# Altium schematic sheet Microcontroller.SchDoc
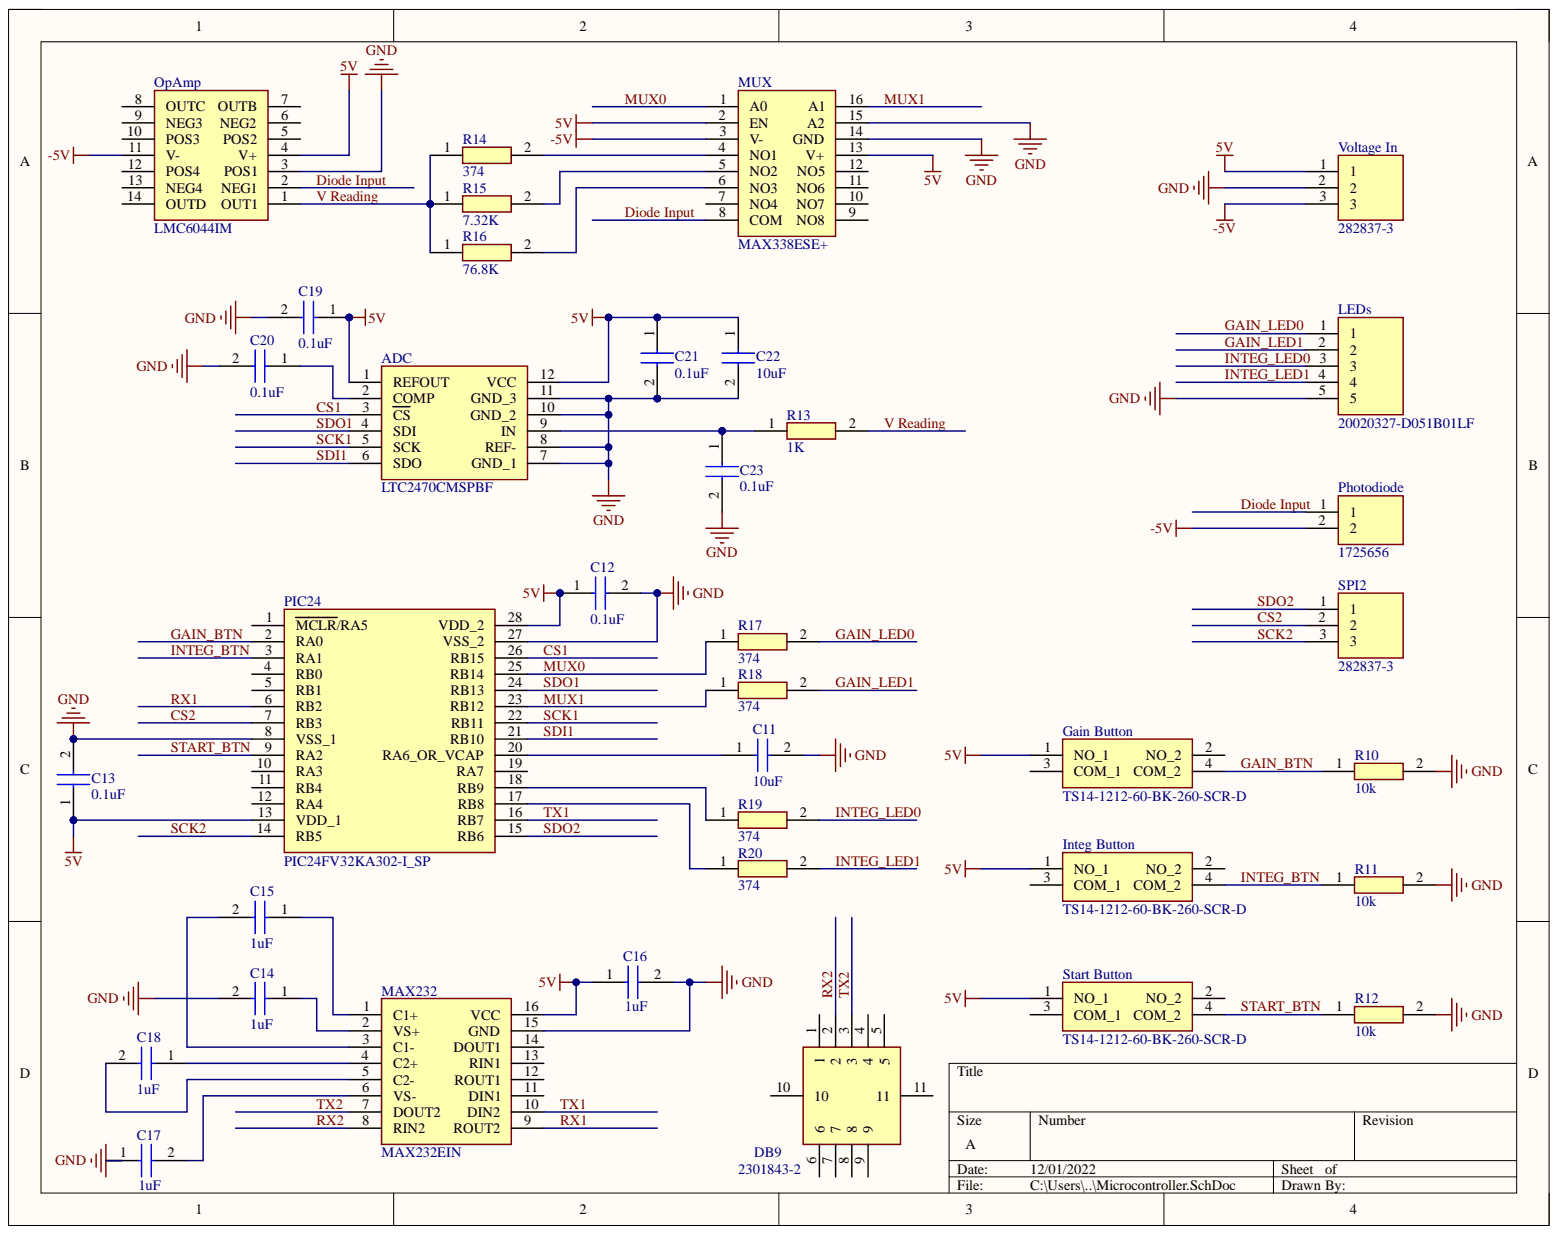
[diagram p0: Microcontroller.SchDoc]

## Parts
ADC  LTC2470CMSPBF  [SOP65P490X110-12N]
C11  10uF  [FG26]
C12  0.1uF  [RDE5C1H100J0P1H03B]
C13  0.1uF  [RDE5C1H100J0P1H03B]
C14  1uF  [K105K20X7RF5TH5]
C15  1uF  [K105K20X7RF5TH5]
C16  1uF  [K105K20X7RF5TH5]
C17  1uF  [K105K20X7RF5TH5]
C18  1uF  [K105K20X7RF5TH5]
C19  0.1uF  [RDE5C1H100J0P1H03B]
C20  0.1uF  [RDE5C1H100J0P1H03B]
C21  0.1uF  [RDE5C1H100J0P1H03B]
C22  10uF  [FG26]
C23  0.1uF  [RDE5C1H100J0P1H03B]
DB9  2301843-2  [23018432]
Gain_Button  TS14-1212-60-BK-260-SCR-D  [TS14121260BK260SCRD]
Integ_Button  TS14-1212-60-BK-260-SCR-D  [TS14121260BK260SCRD]
LEDs  20020327-D051B01LF  [20020327D051B01LF]
MAX232  MAX232EIN  [DIP794W53P254L1930H508Q16N]
MUX  MAX338ESE+  [SOIC127P600X175-16N]
OpAmp  LMC6044IM  [SOIC127P600X175-14N]
Photodiode  1725656  [1725656]
PIC24  PIC24FV32KA302-I_SP  [DIP794W56P254L3486H508Q28N]  (value not drawn)
R10  10k  [RESC3126X65N]
R11  10k  [RESC3126X65N]
R12  10k  [RESC3126X65N]
R13  1K  [RESC5025X65N]
R14  374  [RESC3126X65N]
R15  7.32K  [RESC3126X65N]
R16  76.8K  [ERJP14_(1210)]
R17  374  [RESC3126X65N]
R18  374  [RESC3126X65N]
R19  374  [RESC3126X65N]
R20  374  [RESC3126X65N]
SPI2  282837-3  [2828373]
Start_Button  TS14-1212-60-BK-260-SCR-D  [TS14121260BK260SCRD]
Voltage_In  282837-3  [2828373]

## Nets
5V: ADC.1(REFOUT) ADC.12(VCC) C12.1 C13.1 C16.1 C19.1 C21.1 C22.1 Gain_Button.1(NO_1) Integ_Button.1(NO_1) MAX232.16(VCC) MUX.2(EN) MUX.13(V+) OpAmp.4(V+) PIC24.13(VDD_1) PIC24.28(VDD_2) Start_Button.1(NO_1) Voltage_In.1
-5V: MUX.3(V-) OpAmp.11(V-) Photodiode.2 Voltage_In.3
GND: ADC.7(GND_1) ADC.8(REF-) ADC.10(GND_2) ADC.11(GND_3) C11.2 C12.2 C13.2 C14.2 C16.2 C17.1 C19.2 C20.2 C21.2 C22.2 C23.2 LEDs.5 MAX232.15(GND) MUX.14(GND) MUX.15(A2) OpAmp.3(POS1) PIC24.8(VSS_1) PIC24.27(VSS_2) R10.2 R11.2 R12.2 Voltage_In.2
CS1: ADC.3(CS) PIC24.26(RB15)
CS2: PIC24.7(RB3) SPI2.2
Diode Input: MUX.8(COM) OpAmp.2(NEG1) Photodiode.1
GAIN_BTN: Gain_Button.4(COM_2) PIC24.2(RA0) R10.1
GAIN_LED0: LEDs.1 R17.2
GAIN_LED1: LEDs.2 R18.2
INTEG_BTN: Integ_Button.4(COM_2) PIC24.3(RA1) R11.1
INTEG_LED0: LEDs.3 R19.2
INTEG_LED1: LEDs.4 R20.2
MUX0: MUX.1(A0) PIC24.25(RB14) R17.1
MUX1: MUX.16(A1) PIC24.23(RB12) R18.1
RX1: MAX232.9(ROUT2) PIC24.6(RB2)
RX2: DB9.2 MAX232.8(RIN2)
SCK1: ADC.5(SCK) PIC24.22(RB11)
SCK2: PIC24.14(RB5) SPI2.3
SDI1: ADC.6(SDO) PIC24.21(RB10)
SDO1: ADC.4(SDI) PIC24.24(RB13)
SDO2: PIC24.15(RB6) SPI2.1
START_BTN: PIC24.9(RA2) R12.1 Start_Button.4(COM_2)
TX1: MAX232.10(DIN2) PIC24.16(RB7)
TX2: DB9.3 MAX232.7(DOUT2)
V Reading: OpAmp.1(OUT1) R13.2 R14.1 R15.1 R16.1
NetADC_2: ADC.2(COMP) C20.1
NetADC_9: ADC.9(IN) C23.1 R13.1
NetC11_1: C11.1 PIC24.20(RA6_OR_VCAP)
NetC14_1: C14.1 MAX232.2(VS+)
NetC15_1: C15.1 MAX232.1(C1+)
NetC15_2: C15.2 MAX232.3(C1-)
NetC17_2: C17.2 MAX232.6(VS-)
NetC18_1: C18.1 MAX232.4(C2+)
NetC18_2: C18.2 MAX232.5(C2-)
NetMUX_4: MUX.4(NO1) R14.2
NetMUX_5: MUX.5(NO2) R15.2
NetMUX_6: MUX.6(NO3) R16.2
NetPIC24_17: PIC24.17(RB8) R20.1
NetPIC24_18: PIC24.18(RB9) R19.1

Not connected: DB9 1 4 5 6 7 8 9 10 11; Gain_Button 2(NO_2) 3(COM_1); Integ_Button 2(NO_2) 3(COM_1); MAX232 11(DIN1) 12(ROUT1) 13(RIN1) 14(DOUT1); MUX 7(NO4) 9(NO8) 10(NO7) 11(NO6) 12(NO5); OpAmp 5(POS2) 6(NEG2) 7(OUTB) 8(OUTC) 9(NEG3) 10(POS3) 12(POS4) 13(NEG4) 14(OUTD); PIC24 1(MCLR/RA5) 4(RB0) 5(RB1) 10(RA3) 11(RB4) 12(RA4) 19(RA7); Start_Button 2(NO_2) 3(COM_1)

## Library data of the parts
ADC = LTC2470CMSPBF: Integrated Circuit; Manufacturer_Name: Analog Devices; Component Kind: Standard; Datasheet Link: https://www.analog.com/media/en/technical-documentation/data-sheets/24702fb.pdf; Height: 1.1mm; Manufacturer_Part_Number: LTC2470CMSPBF
C11 C22 = FG26X7R1E106KRT06: Capacitor; Manufacturer_Name: TDK; Arrow Part Number: FG26X7R1E106KRT06; Arrow Price/Stock: https://www.arrow.com/en/products/fg26x7r1e106krt06/tdk?region=nac; Component Kind: Standard; Datasheet Link: https://product.tdk.com/system/files/dam/doc/product/capacitor/ceramic/lead-mlcc/catalog/leadmlcc_halogenfree_fg_en.pdf; Height: 6mm; Manufacturer_Part_Number: FG26X7R1E106KRT06; Mouser Part Number: 810-FG26X7R1E106KRT6; Mouser Price/Stock: https://www.mouser.co.uk/ProductDetail/TDK/FG26X7R1E106KRT06?qs=qf2ddTMq67Uj8zbJHhN20w%3D%3D
C12 C13 C19-C21 C23 = RDER71E104K0P1H03B: Capacitor; Manufacturer_Name: Murata Electronics; Arrow Part Number: RDER71E104K0P1H03B; Arrow Price/Stock: https://www.arrow.com/en/products/rder71e104k0p1h03b/murata-manufacturing?region=nac; Component Kind: Standard; Datasheet Link: https://psearch.en.murata.com/capacitor/product/RDER71E104K0P1H03B.html; Height: 6mm; Library Name: SamacSys.SchLib; Manufacturer_Part_Number: RDER71E104K0P1H03B; Mouser Part Number: 81-RDER71E104K0P1H3B; Mouser Price/Stock: https://www.mouser.co.uk/ProductDetail/Murata-Electronics/RDER71E104K0P1H03B?qs=hNud%2FORuBR1RYUkyJwpo2Q%3D%3D
C14-C18 = K105K20X7RF5TH5: Capacitor; Manufacturer_Name: Vishay; Arrow Part Number: K105K20X7RF5TH5; Arrow Price/Stock: https://www.arrow.com/en/products/k105k20x7rf5th5/vishay?region=nac; Component Kind: Standard; Datasheet Link: http://www.vishay.com/docs/45171/kseries.pdf; Height: 7.6mm; Library Name: SamacSys.SchLib; Manufacturer_Part_Number: K105K20X7RF5TH5; Mouser Part Number: 594-K105K20X7RF5TH5; Mouser Price/Stock: https://www.mouser.co.uk/ProductDetail/Vishay-BC-Components/K105K20X7RF5TH5?qs=9GkFbIK8PKBCh6iJuZkNpw%3D%3D
DB9 = 2301843-2: Connector; Manufacturer_Name: TE Connectivity; Arrow Part Number: 2301843-2; Arrow Price/Stock: https://www.arrow.com/en/products/2301843-2/te-connectivity?region=nac; Component Kind: Standard; Datasheet Link: http://www.te.com/commerce/DocumentDelivery/DDEController?Action=showdoc&DocId=Customer+Drawing%7F2301843%7FH%7Fpdf%7FEnglish%7FENG_CD_2301843_H.pdf%7F2301843-2; Height: 13mm; Manufacturer_Part_Number: 2301843-2; Mouser Part Number: 571-2301843-2; Mouser Price/Stock: https://www.mouser.co.uk/ProductDetail/TE-Connectivity/2301843-2?qs=YCa%2FAAYMW00LuD28hVcyEQ%3D%3D
Gain_Button Integ_Button Start_Button = TS14-1212-60-BK-260-SCR-D: Switch; Manufacturer_Name: CUI Inc.; Component Kind: Standard; Datasheet Link: https://www.cuidevices.com/product/resource/digikeypdf/ts14.pdf; Height: 6.2mm; Manufacturer_Part_Number: TS14-1212-60-BK-260-SCR-D; Mouser Part Number: 179-TS14121260260SCR; Mouser Price/Stock: https://www.mouser.co.uk/ProductDetail/CUI-Devices/TS14-1212-60-BK-260-SCR-D?qs=t7xnP681wgUp2baUVc57aQ%3D%3D
LEDs = 20020327-D051B01LF: Connector; Manufacturer_Name: Amphenol; Arrow Part Number: 20020327-D051B01LF; Arrow Price/Stock: https://www.arrow.com/en/products/20020327-d051b01lf/amphenol; Component Kind: Standard; Datasheet Link: https://componentsearchengine.com/Datasheets/2/20020327-D051B01LF.pdf; Height: 8.8mm; Manufacturer_Part_Number: 20020327-D051B01LF; Mouser Part Number: 649-220327-D051B01LF; Mouser Price/Stock: https://www.mouser.co.uk/ProductDetail/Amphenol-Anytek/20020327-D051B01LF?qs=qY18JiHxGVtQa1p4zd3KCw%3D%3D
MAX232 = MAX232EIN: Integrated Circuit; Manufacturer_Name: Texas Instruments; Arrow Part Number: MAX232EIN; Arrow Price/Stock: https://www.arrow.com/en/products/max232ein/texas-instruments?region=nac; Component Kind: Standard; Datasheet Link: http://www.ti.com/lit/gpn/max232e; Height: 5.08mm; Library Name: SamacSys.SchLib; Manufacturer_Part_Number: MAX232EIN; Mouser Part Number: 595-MAX232EIN; Mouser Price/Stock: https://www.mouser.co.uk/ProductDetail/Texas-Instruments/MAX232EIN?qs=iSMark9AYDXVl0IorFWbQQ%3D%3D
MUX = MAX338ESE+: Integrated Circuit; Manufacturer_Name: Maxim Integrated; Component Kind: Standard; Manufacturer_Part_Number: MAX338ESE+; Mouser Part Number: 700-MAX338ESE
OpAmp = LMC6044IM: Integrated Circuit; Manufacturer_Name: Texas Instruments; Component Kind: Standard; Manufacturer_Part_Number: LMC6044IM; Mouser Part Number: 926-LMC6044IM; Mouser Price/Stock: https://www.mouser.com/Search/Refine.aspx?Keyword=926-LMC6044IM
Photodiode = 1725656: Connector; Manufacturer_Name: Phoenix Contact; Arrow Part Number: 1725656; Arrow Price/Stock: https://www.arrow.com/en/products/1725656/phoenix-contact?region=nac; Component Kind: Standard; Datasheet Link: https://www.phoenixcontact.com/online/portal/us/?uri=pxc-oc-itemdetail:pid=1725656&library=usen&pcck=P-11-01-05&tab=1&selectedCategory=ALL; Height: 8.65mm; Manufacturer_Part_Number: 1725656; Mouser Part Number: 651-1725656; Mouser Price/Stock: https://www.mouser.co.uk/ProductDetail/Phoenix-Contact/1725656?qs=Ul7CXFMnlWWQeccayYbRmw%3D%3D
PIC24 = PIC24FV32KA302-I_SP: Integrated Circuit; Manufacturer_Name: Microchip; Manufacturer_Part_Number: PIC24FV32KA302-I/SP; Arrow Part Number: PIC24FV32KA302-I/SP; Arrow Price/Stock: https://www.arrow.com/en/products/pic24fv32ka302-isp/microchip-technology?region=nac; Component Kind: Standard; Datasheet Link: http://uk.rs-online.com/web/p/products/7421195; Height: 5.08mm; Library Name: SamacSys.SchLib; Mouser Part Number: 579-24FV32KA302ISP; Mouser Price/Stock: https://www.mouser.co.uk/ProductDetail/Microchip-Technology/PIC24FV32KA302-I-SP?qs=gdymDg%2FOn%252BGgCTHVXcFTQw%3D%3D
R10-R12 = CRGCQ1210F10K: Resistor; Manufacturer_Name: TE Connectivity; Component Kind: Standard; Datasheet Link: https://4donline.ihs.com/images/VipMasterIC/IC/TYEL/TYEL-S-A0004929575/TYEL-S-A0004929575-1.pdf?hkey=52A5661711E402568146F3353EA87419; Height: 0.65mm; Manufacturer_Part_Number: CRGCQ1210F10K; Mouser Part Number: 279-CRGCQ1210F10K; Mouser Price/Stock: https://www.mouser.co.uk/ProductDetail/TE-Connectivity-Holsworthy/CRGCQ1210F10K?qs=wUXugUrL1qzEZGMtVZbO%2FA%3D%3D
R13 = CRS2010-FX-1001ELF: Resistor; Manufacturer_Name: Bourns; Arrow Part Number: CRS2010-FX-1001ELF; Arrow Price/Stock: https://www.arrow.com/en/products/crs2010-fx-1001elf/bourns; Component Kind: Standard; Datasheet Link: https://ms.componentsearchengine.com/Datasheets/2/CRS2010-FX-1001ELF.pdf; Height: 0.65mm; Manufacturer_Part_Number: CRS2010-FX-1001ELF; Mouser Part Number: 652-CRS2010FX1001ELF; Mouser Price/Stock: https://www.mouser.co.uk/ProductDetail/Bourns/CRS2010-FX-1001ELF?qs=3ZbGupGMFUfCwjV%2FzAIkZA%3D%3D
R14 R15 R17-R20 = RC1210FR-07374RL: Resistor; Manufacturer_Name: KEMET; Component Kind: Standard; Datasheet Link: https://componentsearchengine.com/Datasheets/1/RC1210FR-07374RL.pdf; Height: 0.65mm; Manufacturer_Part_Number: RC1210FR-07374RL; Mouser Part Number: 603-RC1210FR-07374RL; Mouser Price/Stock: https://www.mouser.com/Search/Refine.aspx?Keyword=603-RC1210FR-07374RL
  R14 R17-R20: Library Name: SamacSys.SchLib
R16 = ERJ-P14F7682U: Resistor; Manufacturer_Name: Panasonic; Component Kind: Standard; Datasheet Link: http://industrial.panasonic.com/cdbs/www-data/pdf/RDO0000/AOA0000C331.pdf; Height: 0.7mm; Manufacturer_Part_Number: ERJ-P14F7682U; Mouser Part Number: 667-ERJ-P14F7682U; Mouser Price/Stock: https://www.mouser.com/Search/Refine.aspx?Keyword=667-ERJ-P14F7682U
SPI2 Voltage_In = 282837-3: Connector; Manufacturer_Name: TE Connectivity; Arrow Part Number: 282837-3; Arrow Price/Stock: https://www.arrow.com/en/products/282837-3/te-connectivity?region=nac; Component Kind: Standard; Datasheet Link: http://uk.rs-online.com/web/p/products/7100371P; Height: 10.3mm; Manufacturer_Part_Number: 282837-3; Mouser Part Number: 571-2828373; Mouser Price/Stock: https://www.mouser.co.uk/ProductDetail/TE-Connectivity/282837-3?qs=A%252Bip%252BNCYi6PMRxtBYEOSrQ%3D%3D
